# Altium schematic sheet Arduino_Uno.SchDoc(Arduino_Uno)
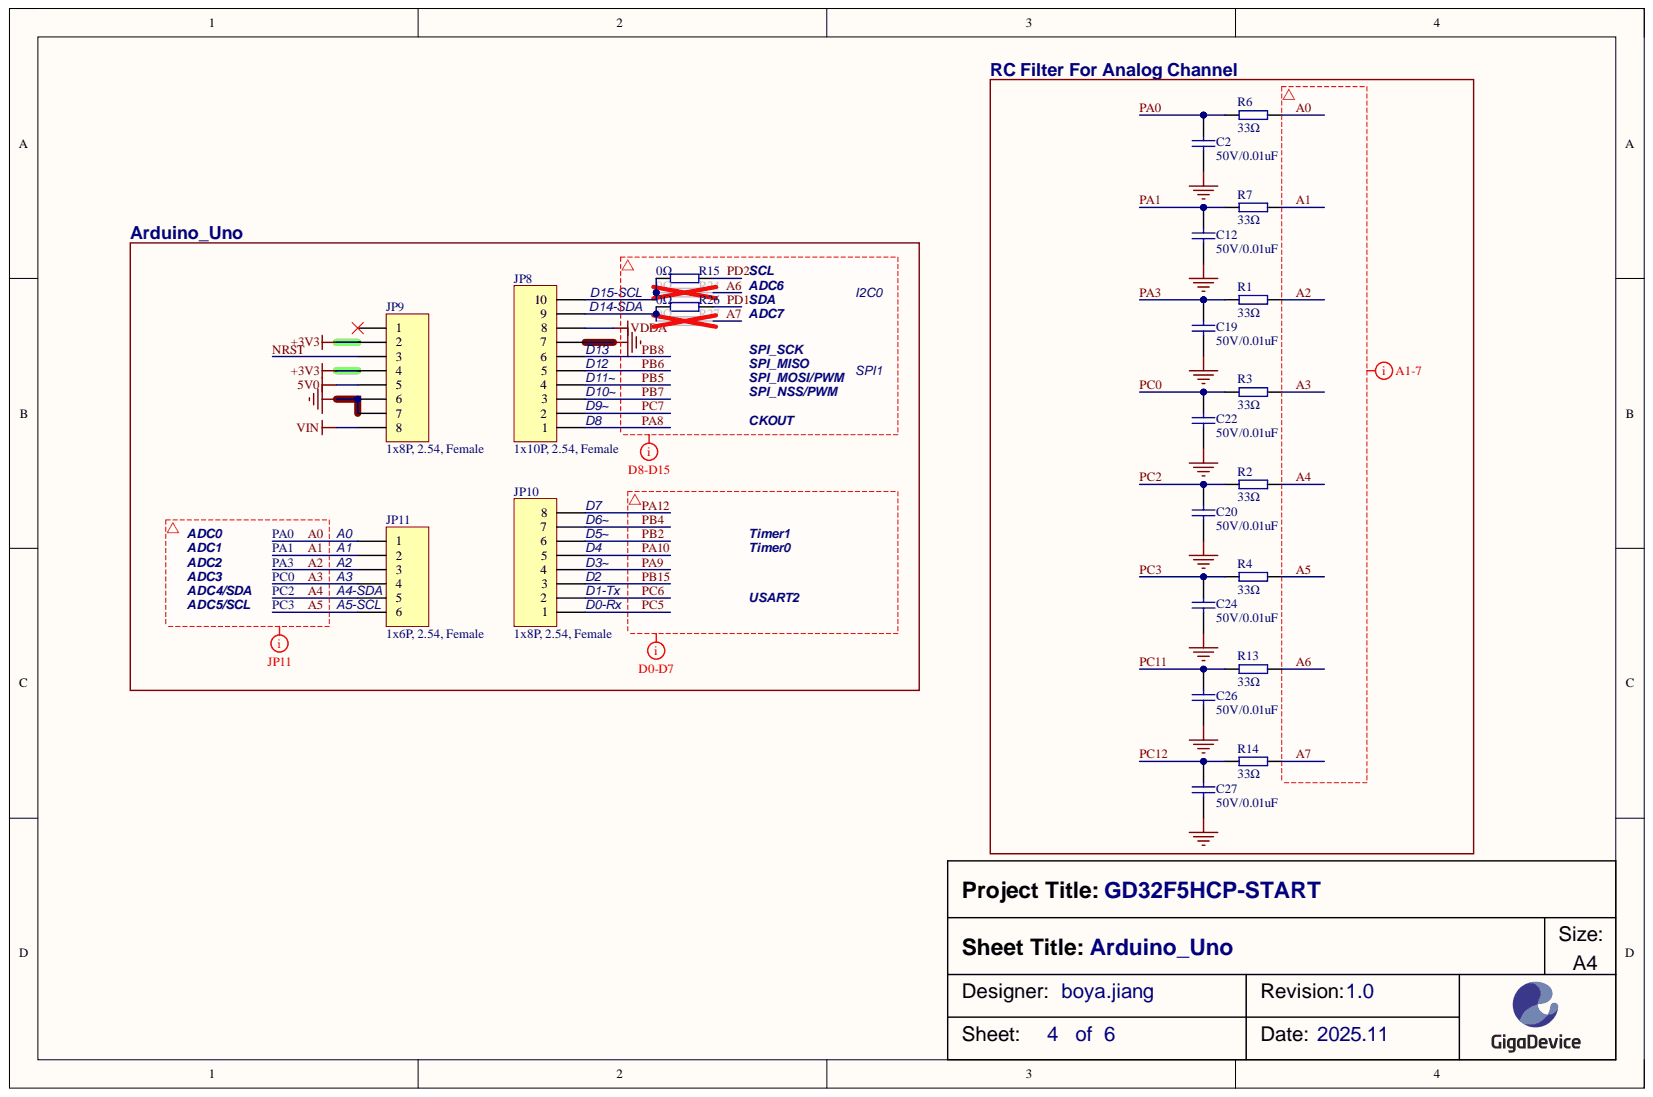
[diagram p0: Arduino_Uno.SchDoc]

## Parts
C2  50V/0.01uF  [0603-C]
C12  50V/0.01uF  [0603-C]
C19  50V/0.01uF  [0603-C]
C20  50V/0.01uF  [0603-C]
C22  50V/0.01uF  [0603-C]
C24  50V/0.01uF  [0603-C]
C26  50V/0.01uF  [0603-C]
C27  50V/0.01uF  [0603-C]
JP8  1x10P, 2.54, Female  [SIP-10, female]
JP9  1x8P, 2.54, Female  [SIP-8, female]
JP10  1x8P, 2.54, Female  [SIP-8, female]
JP11  1x6P, 2.54, Female  [SIP-6, Female]
R1  33Ω  [0603-R]
R2  33Ω  [0603-R]
R3  33Ω  [0603-R]
R4  33Ω  [0603-R]
R6  33Ω  [0603-R]
R7  33Ω  [0603-R]
R13  33Ω  [0603-R]
R14  33Ω  [0603-R]
R15  0Ω  [0603-R]
R24  0Ω  [0603-R]
R26  0Ω  [0603-R]
R27  0Ω  [0603-R]

## Nets
5V0: JP9.5
+3V3: JP9.2 JP9.4
GND: C2.2 C12.2 C19.2 C20.2 C22.2 C24.2 C26.2 C27.2 JP8.7 JP9.6 JP9.7
VDDA: JP8.8
VIN: JP9.8
A0: JP11.1 R6.1
A1: JP11.2 R7.1
A2: JP11.3 R1.1
A3: JP11.4 R3.1
A4: JP11.5 R2.1
A5: JP11.6 R4.1
A6: R13.1 R24.1
A7: R14.1 R27.1
NRST: JP9.3
PA0: C2.1 R6.2
PA1: C12.1 R7.2
PA3: C19.1 R1.2
PA8: JP8.1
PA9: JP10.4
PA10: JP10.5
PA12: JP10.8
PB2: JP10.6
PB4: JP10.7
PB5: JP8.4
PB6: JP8.5
PB7: JP8.3
PB8: JP8.6
PB15: JP10.3
PC0: C22.1 R3.2
PC2: C20.1 R2.2
PC3: C24.1 R4.2
PC5: JP10.1
PC6: JP10.2
PC7: JP8.2
PC11: C26.1 R13.2
PC12: C27.1 R14.2
PD1: R26.1
PD2: R15.1
NetJP8_9: JP8.9 R26.2 R27.2
NetJP8_10: JP8.10 R15.2 R24.2

Not connected: JP9 1

## Library data of the parts
C2 C12 C19 C20 C22 C24 C26 C27 = 50V/0.1uF: 表贴电容; Component Kind: Standard; Library Name: KLTC-电容.SchLib
JP8 = HEADER 10: 直插单排排母; Note: 不焊; Component Kind: Standard; Library Name: KLTC-按键及接插件.SchLib
JP9 JP10 = HEADER 8: 直插单排排母; Note: 不焊; Component Kind: Standard; Library Name: KLTC-按键及接插件.SchLib
JP11 = HEADER 6: 直插单排排母; Note: 不焊; Component Kind: Standard; Library Name: KLTC-按键及接插件.SchLib
R1-R4 R6 R7 R13-R15 R24 R26 R27 = 1MΩ: 表贴电阻; Component Kind: Standard; Library Name: KLTC-电阻.SchLib
